# Altium schematic sheet extBoard_sheet4_adc.SchDoc
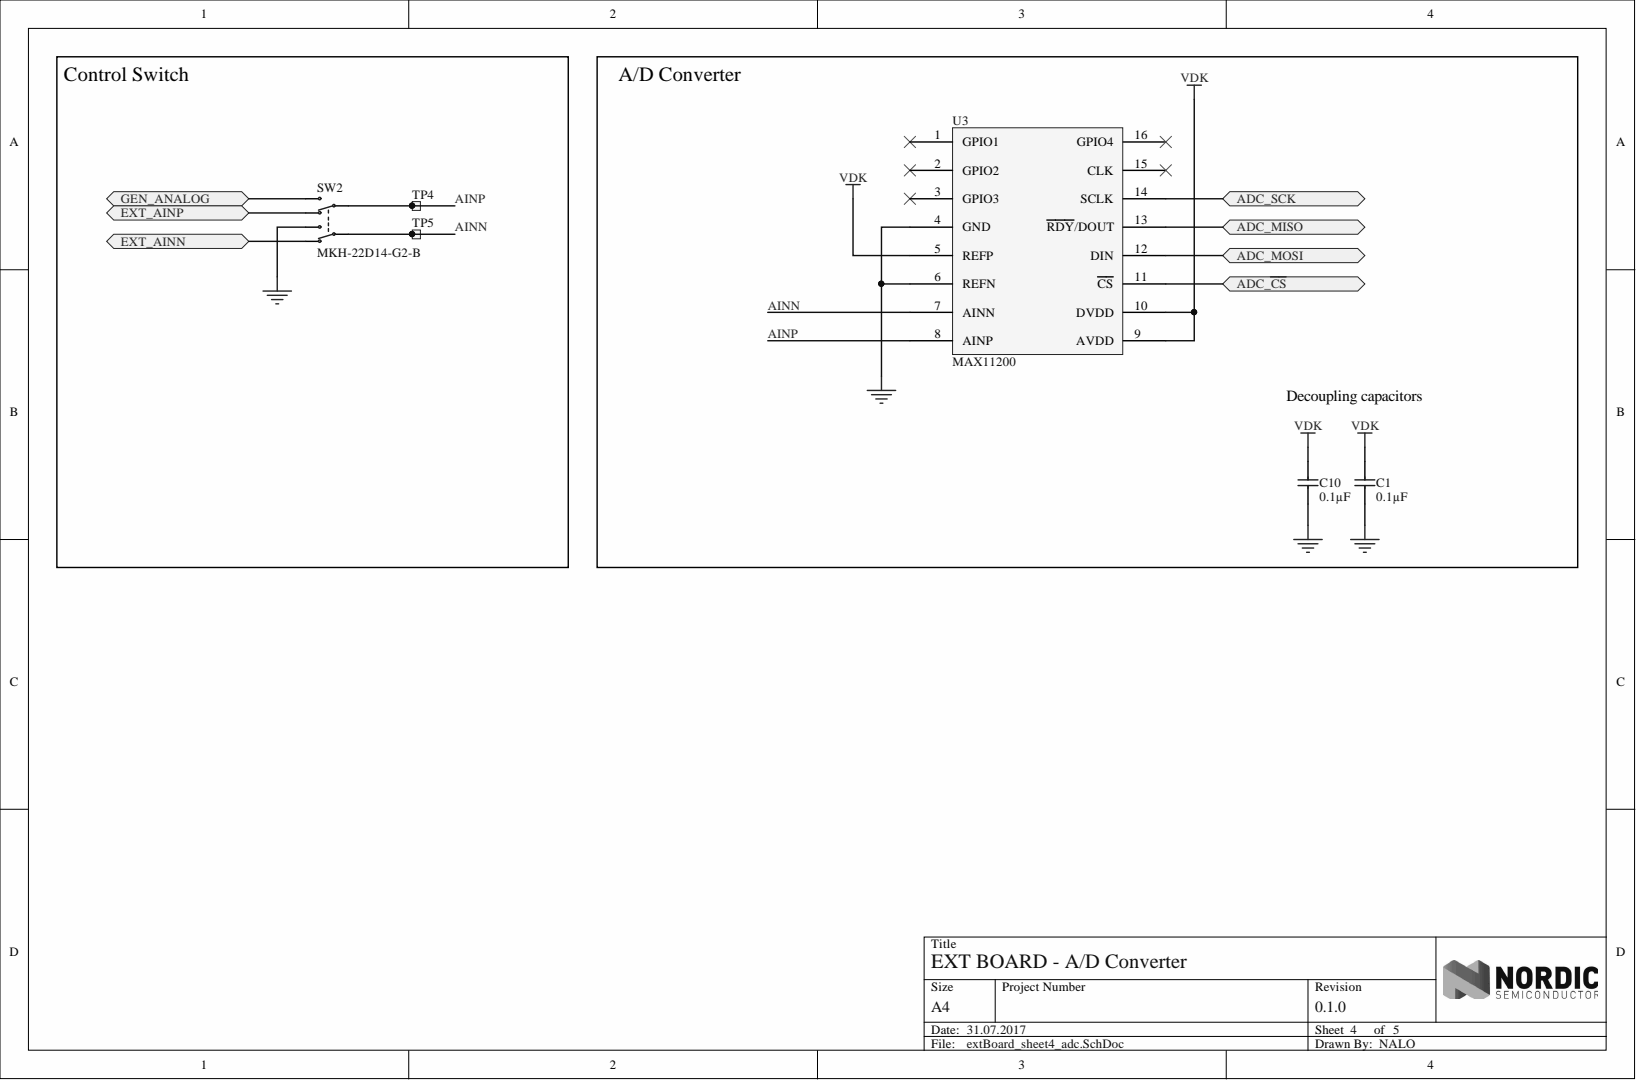
[diagram p0: extBoard_sheet4_adc.SchDoc]

## Parts
C1  0.1µF  [CAPC2012X11L]
C10  0.1µF  [CAPC2012X11L]
SW2  MKH-22D14-G2-B  [MKH-22D14-G2-B]
TP4  TP  [TESTPAD-TH]  (no BOM)
TP5  TP  [TESTPAD-TH]  (no BOM)
U3  MAX11200  [QSOP16]

## Ports
ADC_MISO, ADC_MOSI, ADC_SCK, ADC_~{CS}, EXT_AINN, EXT_AINP, GEN_ANALOG

## Nets
GND: C1.1 C10.1 SW2.1 U3.4(GND) U3.6(REFN)
VDK: C1.2 C10.2 U3.5(REFP) U3.9(AVDD) U3.10(DVDD)
ADC_~{CS}: U3.11(CS) ; port ADC_~{CS}
ADC_MISO: U3.13(RDY/DOUT) ; port ADC_MISO
ADC_MOSI: U3.12(DIN) ; port ADC_MOSI
ADC_SCK: U3.14(SCLK) ; port ADC_SCK
AINN: SW2.2 TP5.1 U3.7(AINN)
AINP: SW2.5 TP4.1 U3.8(AINP)
EXT_AINN: SW2.3 ; port EXT_AINN
EXT_AINP: SW2.6 ; port EXT_AINP
GEN_ANALOG: SW2.4 ; port GEN_ANALOG

Not connected: U3 1(GPIO1) 2(GPIO2) 3(GPIO3) 15(CLK) 16(GPIO4)

## Library data of the parts
C1 C10 = CAP_0805: Capacitor, X5R, ±10%; Part Number: C0805-4µ7; Artikkelnr Noca: 20039266; Artikkelnr Simpro: N.A.; Note: 16V; Component Kind: Standard; Footprint Doc: 0805; LatestRevisionDate: 2013-06-04; Library Name: pca10056.IntLib; Pin Count: 2; Published: 2009-08-21; Value: 0.1µF; Publisher: Nordic Semiconductor
SW2 = MKH-22D14-G2-B: Slide Switch DPDT; Manufacturer: Dailywell; Artikkelnr Noca: 50019112; Artikkelnr Simpro: N.A.; Component Kind: Standard; Datasheet: \\hizz\pro\app9717\Datasheet\switches\Dailywell\MKH-22D14-G2-B.pdf; Footprint Doc: MKH-22D14-G2-B; LatestRevisionDate: 2016-08-18; Library Name: pca10056.IntLib; Manufacturer Part Number: MKH-22D14-G2-B; Part Number: MKH-22D14-G2-B; Pin Count: 6; Published: 2016-08-18; Value: MKH-22D14-G2-B; Publisher: Nordic Semiconductor
TP4 TP5 = Testpoint TH: Testpoint, through hole; Artikkelnr Noca: N.A.; Artikkelnr Simpro: N.A.; Footprint Doc: TESTPAD-TH; LatestRevisionDate: 2009-12-12; Library Name: pca10056.IntLib; Part Number: Testpoint TH; Pin Count: 1; Published: 2009-12-12; Value: TP; Publisher: Nordic Semiconductor
U3 = MAX11200: Component Kind: Standard; Library Name: extBoardLib.SchLib
